# Altium schematic sheet TempIHR.SchDoc(TempIHR)
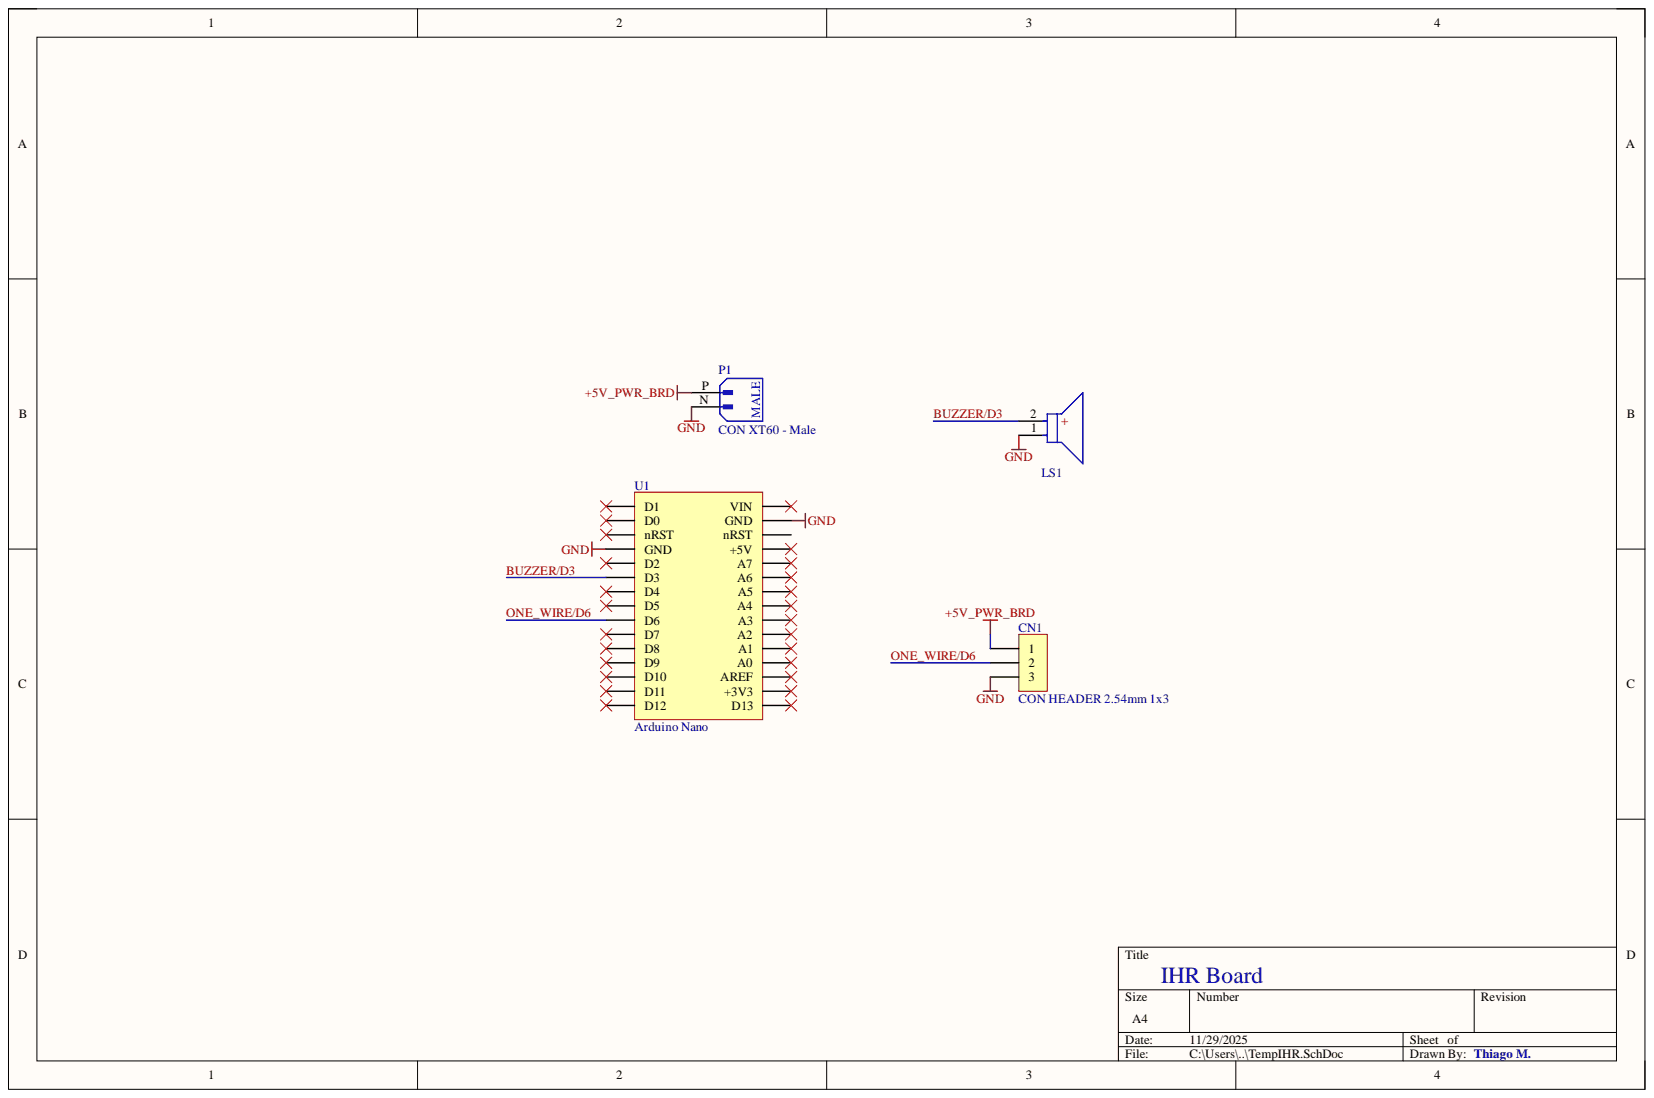
[diagram p0: TempIHR.SchDoc]

## Parts
CN1  CON HEADER 2.54mm 1x3  [CON HEADER 2.54mm 1x3]
LS1  Buzzer  [BUZZER 12MM]  (value not drawn)
P1  CON XT60 - Male  [CON XT60 MALE]
U1  Arduino Nano  [ARDUINO NANO]

## Nets
+5V_PWR_BRD: CN1.1 P1.P
GND: CN1.3 LS1.1 P1.N U1.4, 29(GND)
BUZZER/D3: LS1.2 U1.6(D3)
ONE_WIRE/D6: CN1.2 U1.9(D6)

Not connected: U1 1(D1) 2(D0) 3(nRST) 5(D2) 7(D4) 8(D5) 10(D7) 11(D8) 12(D9) 13(D10) 14(D11) 15(D12) 16(D13) 17(+3V3) 18(AREF) 19(A0) 20(A1) 21(A2) 22(A3) 23(A4) 24(A5) 25(A6) 26(A7) 27(+5V) 28(nRST) 30(VIN)

## Library data of the parts
LS1 = Buzzer: Generic Buzzer; Component Kind: Standard; Library Name: My_Lib.IntLib
U1 = Arduino Nano: Arduino nano.; Component Kind: Standard; Library Name: My_Lib.IntLib
CN1 = CON HEADER 2.54mm 1x3: Component Kind: Standard; Library Name: My_Lib.IntLib
P1 = CON XT60 - Male: Component Kind: Standard; Library Name: My_Lib.IntLib
